# Altium schematic sheet peripheral_1.SchDoc
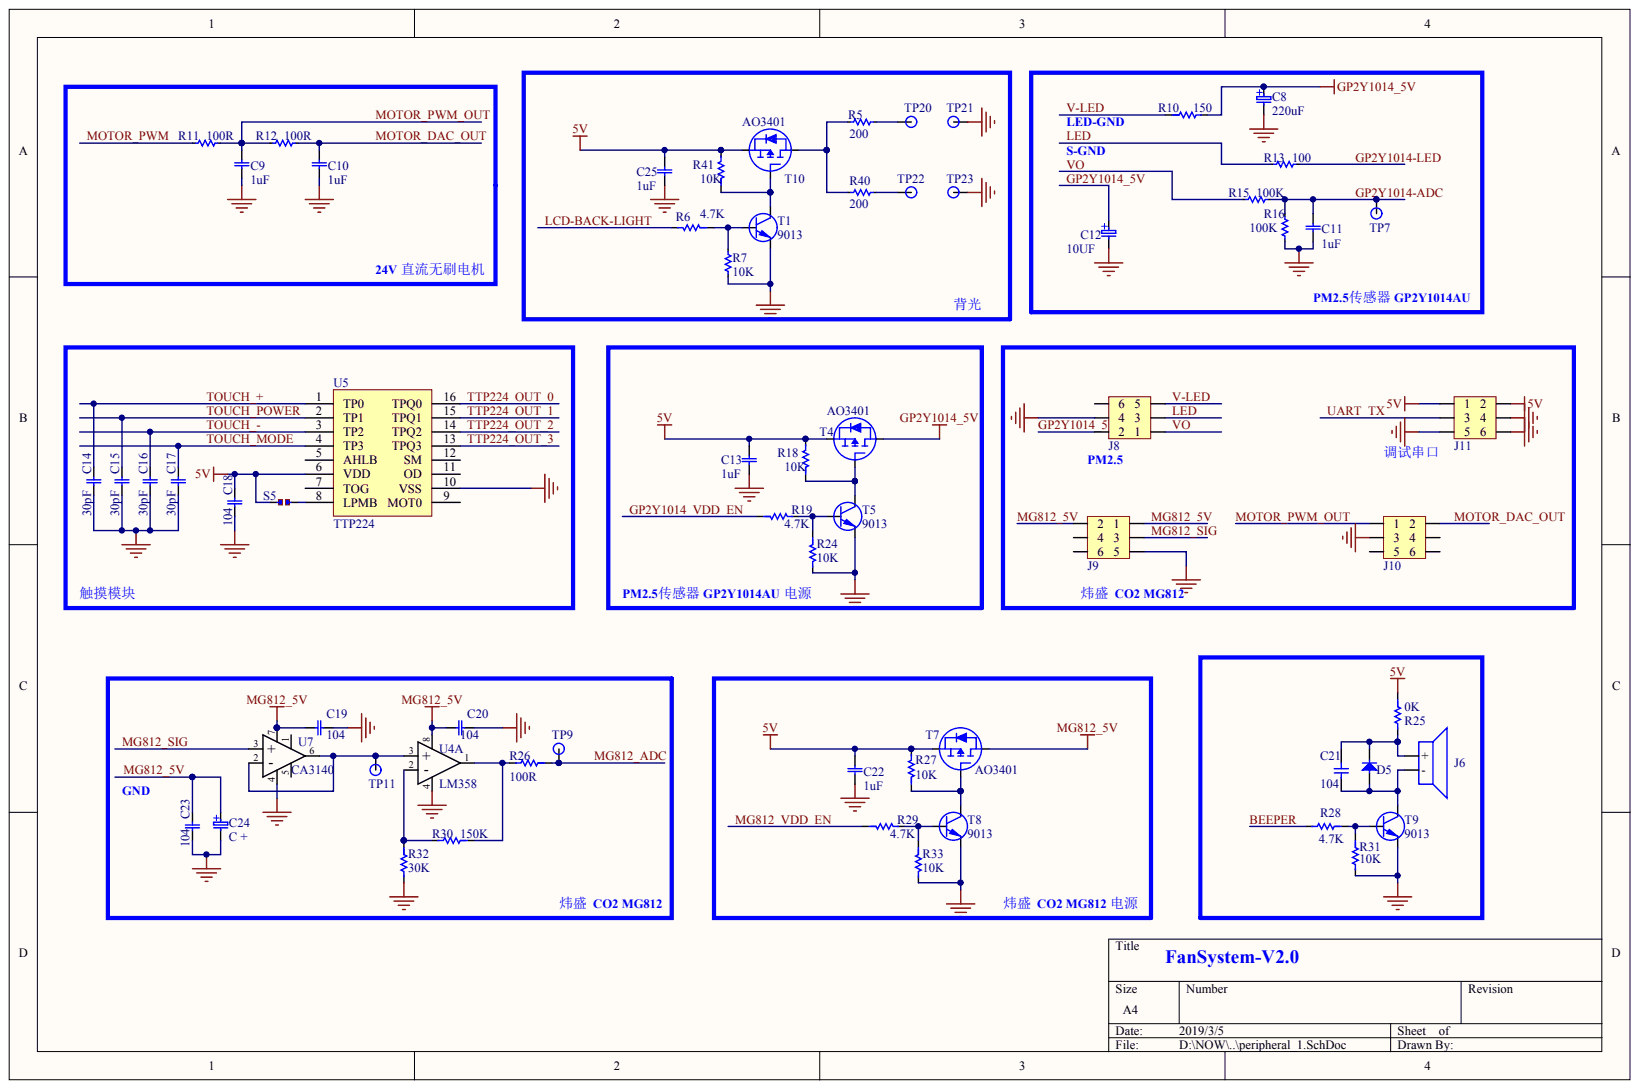
[diagram p0: peripheral_1.SchDoc]

## Parts
C8  220uF
C9  1uF
C10  1uF
C11  1uF
C12  10UF
C13  1uF
C14  30pF
C15  30pF
C16  30pF
C17  30pF
C18  104
C19  104
C20  104
C21  104
C22  1uF
C23  104
C24  C +
C25  1uF
D5  D
J6  BEEPER  (value not drawn)
J8  CON3*2  (value not drawn)
J9  CON3*2  (value not drawn)
J10  CON3*2  (value not drawn)
J11  CON3*2  (value not drawn)
R5  200
R6  4.7K
R7  10K
R10  150
R11  100R
R12  100R
R13  100
R15  100K
R16  100K
R18  10K
R19  4.7K
R24  10K
R25  0K
R26  100R
R27  10K
R28  4.7K
R29  4.7K
R30  150K
R31  10K
R32  30K
R33  10K
R40  200
R41  10K
S5  S
T1  9013
T4  AO3401
T5  9013
T7  AO3401
T8  9013
T9  9013
T10  AO3401
TP7  TP
TP9  TP
TP11  TP
TP20  TP
TP21  TP
TP22  TP
TP23  TP
U4  LM358  (part A)
U5  TTP224
U7  CA3140

## Nets
5V: C13.2 C18.2 C22.2 C25.2 J11.1 J11.2 R18.1 R25.2 R27.1 R41.1 S5.1 T4.S T7.S T10.S U5.6(VDD)
GND: C8.K C9.1 C10.1 C11.1 C12.K C13.1 C14.1 C15.1 C16.1 C17.1 C18.1 C19.2 C20.2 C22.1 C23.2 C24.K C25.1 J8.4 J9.5 J10.3 J11.4 J11.5 J11.6 R7.1 R16.1 R24.1 R31.1 R32.1 R33.1 T1.2 T5.2 T8.2 T9.2 TP21.1 TP23.1 U4.4 U5.10(VSS) U7.4
BEEPER: R28.1
GP2Y1014-ADC: C11.2 R15.2 R16.2 TP7.1
GP2Y1014-LED: R13.2
GP2Y1014_5V: C8.A C12.A J8.2 R10.1 T4.D
GP2Y1014_VDD_EN: R19.2
LCD-BACK-LIGHT: R6.1
LED: J8.3 R13.1
MG812_5V: C19.1 C20.1 C23.1 C24.A J9.1 J9.2 T7.D U4.8 U7.7
MG812_ADC: R26.2 TP9.1
MG812_SIG: J9.3 U7.3(+)
MG812_VDD_EN: R29.2
MOTOR_DAC_OUT: C10.2 J10.2 R12.2
MOTOR_PWM: R11.1
MOTOR_PWM_OUT: C9.2 J10.1 R11.2 R12.1
TOUCH_+: C14.2 U5.1(TP0)
TOUCH_-: C16.2 U5.3(TP2)
TOUCH_MODE: C17.2 U5.4(TP3)
TOUCH_POWER: C15.2 U5.2(TP1)
TTP224_OUT_0: U5.16(TPQ0)
TTP224_OUT_1: U5.15(TPQ1)
TTP224_OUT_2: U5.14(TPQ2)
TTP224_OUT_3: U5.13(TPQ3)
UART_TX: J11.3
V-LED: J8.5 R10.2
VO: J8.1 R15.1
NetC21_1: C21.1 D5.K J6.1 R25.1
NetC21_2: C21.2 D5.A J6.2 T9.3
NetR5_1: R5.1 TP20.1
NetR5_2: R5.2 R40.2 T10.D
NetR6_2: R6.2 R7.2 T1.1
NetR18_2: R18.2 T4.G T5.3
NetR19_1: R19.1 R24.2 T5.1
NetR26_1: R26.1 R30.2 U4.1
NetR27_2: R27.2 T7.G T8.3
NetR28_2: R28.2 R31.2 T9.1
NetR29_1: R29.1 R33.2 T8.1
NetR30_1: R30.1 R32.2 U4.2(-)
NetR40_1: R40.1 TP22.1
NetR41_2: R41.2 T1.3 T10.G
NetS5_2: S5.2 U5.8(LPMB)
NetTP11_1: TP11.1 U4.3(+) U7.2(-) U7.6

Not connected: J8 6; J9 4 6; J10 4 5 6; U5 5(AHLB) 7(TOG) 9(MOT0) 11(OD) 12(SM); U7 1 5
